# Altium schematic sheet Power,USB_and_Sensor.SchDoc
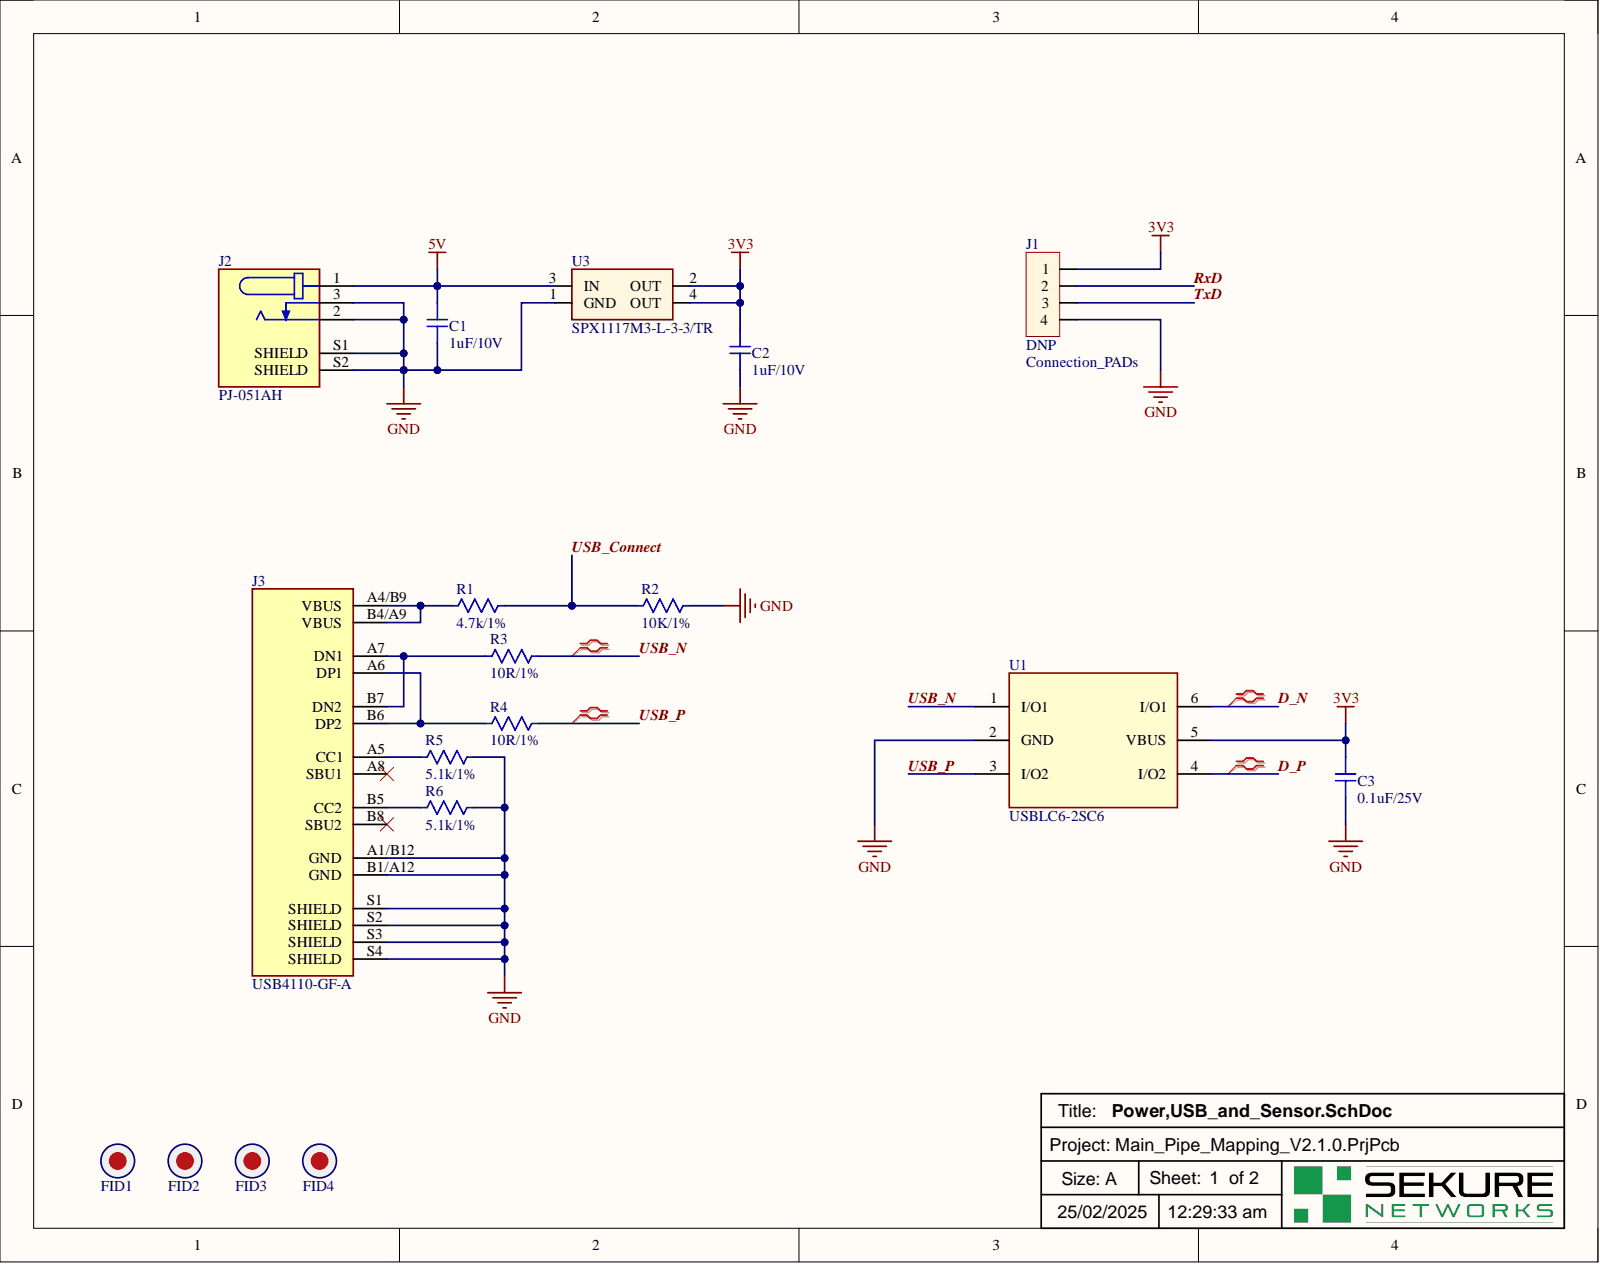
[diagram p0: Power,USB_and_Sensor.SchDoc]

## Parts
C1  value 1uF/10V  [CAP 0603]  (hidden comment: Ceramic Capacitor)
C2  value 1uF/10V  [CAP 0603]  (hidden comment: Ceramic Capacitor)
C3  value 0.1uF/25V  [CAP 0603]  (hidden comment: Ceramic Capacitor)
FID1  Fiducal marks  [Fiducial mark]  (value not drawn)  (no BOM)
FID2  Fiducal marks  [Fiducial mark]  (value not drawn)  (no BOM)
FID3  Fiducal marks  [Fiducial mark]  (value not drawn)  (no BOM)
FID4  Fiducal marks  [Fiducial mark]  (value not drawn)  (no BOM)
J1  value Connection_PADs  Assembly: DNP  [Conn_Pad Small]  (hidden comment: Connector)
J2  value PJ-051AH  [PJ-051AH]  (hidden comment: Connector)
J3  value USB4110-GF-A  [USB4110GFA]  (hidden comment: Connector)
R1  value 4.7k/1%  [RES 0603]  (hidden comment: Resistor)
R2  value 10K/1%  [RES 0603]  (hidden comment: Resistor)
R3  value 10R/1%  [RES 0603]  (hidden comment: Resistor)
R4  value 10R/1%  [RES 0603]  (hidden comment: Resistor)
R5  value 5.1k/1%  [RES 0603]  (hidden comment: Resistor)
R6  value 5.1k/1%  [RES 0603]  (hidden comment: Resistor)
U1  value USBLC6-2SC6  [SOT23-6L]  (hidden comment: TVS Diode)
U3  value SPX1117M3-L-3-3/TR  [SOT-223]  (hidden comment: Regulator)

## Nets
3V3: C2.1 C3.1 J1.1 U1.5(VBUS) U3.2(OUT) U3.4(OUT)
5V: C1.1 J2.1 U3.3(IN)
GND: C1.2 C2.2 C3.2 J1.4 J2.2 J2.3 J2.S1(SHIELD) J2.S2(SHIELD) J3.A1/B12(GND) J3.B1/A12(GND) J3.S1(SHIELD) J3.S2(SHIELD) J3.S3(SHIELD) J3.S4(SHIELD) R2.2 R5.2 R6.1 U1.2(GND) U3.1(GND)
D_N: U1.6(I/O1)
D_P: U1.4(I/O2)
RxD: J1.2
TxD: J1.3
USB_Connect: R1.2 R2.1
USB_N: R3.1 U1.1(I/O1)
USB_P: R4.1 U1.3(I/O2)
NetJ3_A4/B9: J3.A4/B9(VBUS) J3.B4/A9(VBUS) R1.1
NetJ3_A5: J3.A5(CC1) R5.1
NetJ3_A6: J3.A6(DP1) J3.B6(DP2) R4.2
NetJ3_A7: J3.A7(DN1) J3.B7(DN2) R3.2
NetJ3_B5: J3.B5(CC2) R6.2

Not connected: FID1 0; FID2 0; FID3 0; FID4 0; J3 A8(SBU1) B8(SBU2)

## Library data of the parts
C1 C2 = CL10B105KP8NNNC: Multilayer Ceramic Capacitor, 1 uF, 10 V, ± 10%, X7R, 0603 [1608 Metric]; Manufacturer: Samsung; Material: 4241ConductorMaterial; Voltage Rating: 10V; Case Code (Imperial): 0603; Case Code (Metric): 1608; Case/Package: 0603; Category: Ceramic Capacitors; Component Kind: Standard; Construction: Flat; Depth: 0.8mm; Dielectric: X7R; DigiKey: 1276-1946-1-ND; Height: 0.8mm; Lead Free: Lead Free; Length: 1.6mm; Library Name: Ceramic Capacitors.IntLib; Max Operating Temperature: 125°C; Min Operating Temperature: -55°C; Mounting Technology: Surface Mount; P/N: CL10B105KP8NNNC; Package Quantity: 1; Packaging: Tape and Reel; Pricing: 1=0.1, 10=0.026, 50=0.0146, 100=0.0114, 500=0.00858, 1000=0.00674 (USD); Radiation Hardening: No; RoHS: Yes; RoHS Compliant: Yes; Series: CL; Stock: 7012743; Supplier: Digikey; Thickness: 0.035inch; Tolerance: 10%; Voltage Rating (DC): 10V; Width: 0.031inch
C3 = CL10B104KA8NNNC: Multilayer Ceramic Capacitor, 0.1 uF, 25 V, ± 10%, X7R, 0603 [1608 Metric]; Manufacturer: Samsung; Material: 4241ConductorMaterial; Voltage: 25V; Case Code (Imperial): 0603; Case Code (Metric): 1608; Case/Package: 0603; Category: Ceramic Capacitors; Component Kind: Standard; ComponentLink1Description: https://datasheet.ciiva.com/25805/cl10b104ka8nnnc-25805120.pdf?src-supplier=Digikey; ComponentLink1URL: https://datasheet.ciiva.com/25805/cl10b104ka8nnnc-25805120.pdf?src-supplier=Digikey; ComponentLink2Description: https://datasheet.ciiva.com/26838/getdatasheetpartid-354050-26838409.pdf?src-supplier=Verical; ComponentLink2URL: https://datasheet.ciiva.com/26838/getdatasheetpartid-354050-26838409.pdf?src-supplier=Verical; Depth: 0.8mm; Dielectric: X7R; DigiKey: 1276-1006-1-ND; Height: 0.8mm; Lead Free: Lead Free; Length: 1.6mm; Library Name: Ceramic Capacitors.IntLib; Max Operating Temperature: 125°C; Min Operating Temperature: -55°C; Mounting Technology: Surface Mount; P/N: CL10B104KA8NNNC; Package Quantity: 1; Packaging: Tape and Reel; Pricing: 1=0.1, 10=0.024, 50=0.0136, 100=0.0105, 500=0.00792, 1000=0.00622 (USD); Radiation Hardening: No; RoHS: Yes; RoHS Compliant: Yes; Series: CL; Stock: 708971; Supplier: Digikey; Thickness: 0.035inch; Tolerance: 10%; Voltage Rating: 25V; Voltage Rating (DC): 25V; Width: 0.031inch
FID1-FID4 = Fiducial mark: Fiducial mark mini  in top placement.; Number: 1; Library Name: Connectors.IntLib
J1 = Conc_Pad_Small: 4 PCB SMD PADs for Connection; Component Kind: Standard; ComponentLink1Description: https://datasheet.ciiva.com/5741/1605505-5741440.pdf?src-supplier=Farnell; ComponentLink1URL: https://datasheet.ciiva.com/5741/1605505-5741440.pdf?src-supplier=Farnell; ComponentLink2Description: https://datasheet.ciiva.com/30254/eng-cs-1308389-eurostyle-terminal-blocks-0607-30254374.pdf?src-supplier=Onlinecomponents.com; ComponentLink2URL: https://datasheet.ciiva.com/30254/eng-cs-1308389-eurostyle-terminal-blocks-0607-30254374.pdf?src-supplier=Onlinecomponents.com; ComponentLink3Description: https://datasheet.ciiva.com/3141/eng-cs-1308389-eurostyle-terminal-blocks-0607-3141117.pdf?src-supplier=Avnet; ComponentLink3URL: https://datasheet.ciiva.com/3141/eng-cs-1308389-eurostyle-terminal-blocks-0607-3141117.pdf?src-supplier=Avnet; ComponentLink4Description: https://datasheet.ciiva.com/14609/70087596-14609846.pdf?src-supplier=Allied+Electronics; ComponentLink4URL: https://datasheet.ciiva.com/14609/70087596-14609846.pdf?src-supplier=Allied+Electronics; ComponentLink5Description: https://datasheet.ciiva.com/823/eng-cd-282834-c1-823474.pdf?src-supplier=Digikey; ComponentLink5URL: https://datasheet.ciiva.com/823/eng-cd-282834-c1-823474.pdf?src-supplier=Digikey; Library Name: Connectors.IntLib
J2 = PJ-051AH: 2.0 x 6.0 mm, 5.0 A, Horizontal, Through Hole, Shielded, Dc Power Jack Connector; Manufacturer: CUI Devices; Category: Power Connectors; Component Kind: Standard; ComponentLink1Description: https://www.cuidevices.com/product/resource/digikeypdf/pj-051ah.pdf?src-supplier=Digikey; ComponentLink1URL: https://www.cuidevices.com/product/resource/digikeypdf/pj-051ah.pdf?src-supplier=Digikey; ComponentLink2Description: https://datasheet.octopart.com/PJ-051AH-CUI-Devices-datasheet-137760691.pdf?src-supplier=Future+Electronics; ComponentLink2URL: https://datasheet.octopart.com/PJ-051AH-CUI-Devices-datasheet-137760691.pdf?src-supplier=Future+Electronics; ComponentLink3Description: https://datasheet.octopart.com/PJ-051AH-CUI-Devices-datasheet-141000361.pdf?src-supplier=Master+Electronics; ComponentLink3URL: https://datasheet.octopart.com/PJ-051AH-CUI-Devices-datasheet-141000361.pdf?src-supplier=Master+Electronics; DigiKey: CP-051AH-ND; Library Name: Connectors.IntLib; P/N: PJ-051AH; Pricing: 1=0.63, 10=0.532, 25=0.5108, 50=0.4998, 100=0.4781, 250=0.43464, 500=0.39118, 1000=0.38261 (USD); RoHS: Yes; Stock: 71631; Supplier: Digikey
J3 = USB4110-GF-A: USB Connector, 16 Contact(s), Male, Right Angle, Surface Mount Terminal, Locking, Receptacle; Manufacturer: Global Connector Technology; Category: USB Connectors; Component Kind: Standard; ComponentLink1Description: https://gct.co/files/drawings/usb4110.pdf?src-supplier=Digikey; ComponentLink1URL: https://gct.co/files/drawings/usb4110.pdf?src-supplier=Digikey; DigiKey: 2073-USB4110-GF-A-1-ND; Library Name: Connectors.IntLib; P/N: USB4110-GF-A; Pricing: 1=1.35, 10=1.143, 25=1.0964, 50=1.0732, 100=1.0266, 250=0.93324, 500=0.83992 (USD); RoHS: No; Stock: 41079; Supplier: Digikey
R1 = ERJ-3EKF4701V: RES SMD, 4.7K 0603, 0.1W, 1%; Manufacturer: Panasonic; Max Power Dissipation: 100mW; Resistance: 4.7kR; Temperature Coefficient: 100ppm/°C; Voltage Rating: 75V; Case Code (Imperial): 0603; Case Code (Metric): 1608; Case/Package: 0603; Component Kind: Standard; ComponentLink1Description: https://datasheet.ciiva.com/16449/aoa0000ce2-16449479.pdf?src-supplier=Future+Electronics; ComponentLink1URL: https://datasheet.ciiva.com/16449/aoa0000ce2-16449479.pdf?src-supplier=Future+Electronics; ComponentLink2Description: https://datasheet.ciiva.com/16511/aoa0000ce2-16511783.pdf?src-supplier=Avnet+Asia; ComponentLink2URL: https://datasheet.ciiva.com/16511/aoa0000ce2-16511783.pdf?src-supplier=Avnet+Asia; ComponentLink3Description: https://datasheet.ciiva.com/9195/aoa0000ce2-9195740.pdf?src-supplier=Avnet; ComponentLink3URL: https://datasheet.ciiva.com/9195/aoa0000ce2-9195740.pdf?src-supplier=Avnet; ComponentLink4Description: https://datasheet.ciiva.com/16758/70260748-16758189.pdf?src-supplier=Allied+Electronics; ComponentLink4URL: https://datasheet.ciiva.com/16758/70260748-16758189.pdf?src-supplier=Allied+Electronics; ComponentLink5Description: https://datasheet.ciiva.com/24160/aoa0000ce2-24160445.pdf?src-supplier=Mouser; ComponentLink5URL: https://datasheet.ciiva.com/24160/aoa0000ce2-24160445.pdf?src-supplier=Mouser; ComponentLink6Description: https://datasheet.ciiva.com/46372/1678782-46372717.pdf?src-supplier=Element14; ComponentLink6URL: https://datasheet.ciiva.com/46372/1678782-46372717.pdf?src-supplier=Element14; ComponentLink7Description: https://datasheet.ciiva.com/17213/aoa0000ce2-17213182.pdf?src-supplier=Avnet+Europe; ComponentLink7URL: https://datasheet.ciiva.com/17213/aoa0000ce2-17213182.pdf?src-supplier=Avnet+Europe; ComponentLink8Description: https://datasheet.ciiva.com/7830/426256-7830985.pdf?src-supplier=Element14; ComponentLink8URL: https://datasheet.ciiva.com/7830/426256-7830985.pdf?src-supplier=Element14; ComponentLink9Description: https://datasheet.ciiva.com/46372/1678782-46372716.pdf?src-supplier=Element14; ComponentLink9URL: https://datasheet.ciiva.com/46372/1678782-46372716.pdf?src-supplier=Element14; ComponentLink10Description: https://datasheet.ciiva.com/7830/aoa0000ce2-7830735.pdf?src-supplier=Element14; ComponentLink10URL: https://datasheet.ciiva.com/7830/aoa0000ce2-7830735.pdf?src-supplier=Element14; Composition: Thick Film; Contact Plating: Tin; Depth: 0.8mm; DigiKey: P4.70KHDKR-ND; Features: Automotive AEC-Q200; Height: 0.022inch; Lead Free: Lead Free; Length: 1.6mm; Library Name: Resistors.IntLib; Max Operating Temperature: 155°C; Military Standard: Not; Min Operating Temperature: -55°C; Mount: Surface Mount; Number of Pins: 2; Number of Terminations: 2; P/N: ERJ-3EKF4701V; Package Quantity: 1; Packaging: Tape and Reel; Power Rating: 100mW; Pricing 1: 1=0.10000, 10=0.05700, 100=0.02340, 1000=0.01049, 2500=0.00911 (USD); Radiation Hardening: No; REACH SVHC: No SVHC; RoHS: Yes; Stock 1: 588466; Supplier 1: Digi-Key; Termination: SMD/SMT; Tolerance: 1%; Voltage Rating (DC): 75V; Weight: 7.1E-05oz; Width: 0.031inch
R2 = RC0603FR-0710KL: RES SMD 10K OHM 1% 1/10W 0603; Manufacturer: Yageo; Power Rating: 100mW; Resistance: 10kR; Temperature Coefficient: 100ppm/°C; Voltage Rating: 75V; Voltage Rating (DC): 50V; Case Code (Imperial): 0603; Case Code (Metric): 1608; Case/Package: 0603; Component Kind: Standard; ComponentLink1Description: https://datasheet.ciiva.com/7660/38590-7660789.pdf?src-supplier=Element14; ComponentLink1URL: https://datasheet.ciiva.com/7660/38590-7660789.pdf?src-supplier=Element14; ComponentLink2Description: https://datasheet.ciiva.com/15189/getdatasheetpartid-409289-15189591.pdf?src-supplier=Verical; ComponentLink2URL: https://datasheet.ciiva.com/15189/getdatasheetpartid-409289-15189591.pdf?src-supplier=Verical; ComponentLink3Description: https://datasheet.ciiva.com/46440/1868787-46440891.pdf?src-supplier=Element14; ComponentLink3URL: https://datasheet.ciiva.com/46440/1868787-46440891.pdf?src-supplier=Element14; ComponentLink4Description: https://datasheet.ciiva.com/7983/443971-7983596.pdf?src-supplier=Element14; ComponentLink4URL: https://datasheet.ciiva.com/7983/443971-7983596.pdf?src-supplier=Element14; ComponentLink5Description: https://datasheet.ciiva.com/7746/1738695-7746239.pdf?src-supplier=Element14; ComponentLink5URL: https://datasheet.ciiva.com/7746/1738695-7746239.pdf?src-supplier=Element14; ComponentLink6Description: https://datasheet.ciiva.com/22747/443971-22747519.pdf?src-supplier=Element14; ComponentLink6URL: https://datasheet.ciiva.com/22747/443971-22747519.pdf?src-supplier=Element14; Composition: Thick Film; Depth: 0.8mm; DigiKey: 311-10.0KHRDKR-ND; Height: 0.45mm; Lead Free: Lead Free; Lead Pitch: 0.3mm; Length: 1.6mm; Library Name: Resistors.IntLib; Max Operating Temperature: 155°C; Military Standard: Not; Min Operating Temperature: -55°C; Number of Pins: 2; P/N: RC0603FR-0710KL; Packaging: Tape and Reel; Pricing 1: 1=0.10000, 10=0.02300, 100=0.00940, 1000=0.00421, 2500=0.00366 (USD); REACH SVHC: No SVHC; RoHS: Yes; Stock 1: 55833360; Supplier 1: Digi-Key; Termination: SMD/SMT; Tolerance: 1%; Weight: 7.1E-05oz; Width: 0.8mm
R3 R4 = CRCW060310R0FKEA: RES SMD 10 OHM 1% 1/10W 0603; Manufacturer: Vishay; Max Power Dissipation: 100mW; Resistance: 10R; Temperature Coefficient: 100ppm/°C; Voltage Rating: 75V; Case Code (Imperial): 0603; Case Code (Metric): 1608; Case/Package: 0603; Component Kind: Standard; ComponentLink1Description: https://datasheet.ciiva.com/11808/0900766b80d98afc-11808660.pdf?src-supplier=RSComponents; ComponentLink1URL: https://datasheet.ciiva.com/11808/0900766b80d98afc-11808660.pdf?src-supplier=RSComponents; ComponentLink2Description: https://datasheet.ciiva.com/2465/dcrcwe3-2465699.pdf?src-supplier=Digi-Key; ComponentLink2URL: https://datasheet.ciiva.com/2465/dcrcwe3-2465699.pdf?src-supplier=Digi-Key; ComponentLink3Description: https://datasheet.ciiva.com/2465/dcrcwe3-2465701.pdf?src-supplier=Digi-Key; ComponentLink3URL: https://datasheet.ciiva.com/2465/dcrcwe3-2465701.pdf?src-supplier=Digi-Key; ComponentLink4Description: https://datasheet.ciiva.com/16740/dcrcwe3-16740661.pdf?src-supplier=Future+Electronics; ComponentLink4URL: https://datasheet.ciiva.com/16740/dcrcwe3-16740661.pdf?src-supplier=Future+Electronics; ComponentLink5Description: https://datasheet.ciiva.com/26316/fld-crcw-e3-data-en-26316582.pdf?src-supplier=Distrelec; ComponentLink5URL: https://datasheet.ciiva.com/26316/fld-crcw-e3-data-en-26316582.pdf?src-supplier=Distrelec; ComponentLink6Description: https://datasheet.ciiva.com/16781/70201119-16781847.pdf?src-supplier=Allied+Electronics; ComponentLink6URL: https://datasheet.ciiva.com/16781/70201119-16781847.pdf?src-supplier=Allied+Electronics; ComponentLink7Description: https://datasheet.ciiva.com/22673/1697337-22673071.pdf?src-supplier=Element14; ComponentLink7URL: https://datasheet.ciiva.com/22673/1697337-22673071.pdf?src-supplier=Element14; ComponentLink8Description: https://datasheet.ciiva.com/2465/dcrcwe3-2465700.pdf?src-supplier=Digi-Key; ComponentLink8URL: https://datasheet.ciiva.com/2465/dcrcwe3-2465700.pdf?src-supplier=Digi-Key; ComponentLink9Description: https://datasheet.ciiva.com/3256/dcrcwe3-3256955.pdf?src-supplier=Avnet; ComponentLink9URL: https://datasheet.ciiva.com/3256/dcrcwe3-3256955.pdf?src-supplier=Avnet; ComponentLink10Description: https://datasheet.ciiva.com/5547/dcrcwe3-109170-5547342.pdf?src-supplier=Mouser; ComponentLink10URL: https://datasheet.ciiva.com/5547/dcrcwe3-109170-5547342.pdf?src-supplier=Mouser; Composition: Thick Film; Contact Plating: Tin; Depth: 0.85mm; DigiKey: 541-10.0HDKR-ND; Features: Automotive AEC-Q200; Height: 0.45mm; Lead Free: Lead Free; Length: 1.55mm; Library Name: Resistors.IntLib; Max Operating Temperature: 155°C; Military Standard: Not; Min Operating Temperature: -55°C; Mount: Surface Mount; Number of Pins: 2; Number of Terminations: 2; P/N: CRCW060310R0FKEA; Package Quantity: 10; Packaging: Tape and Reel; Power Rating: 100mW; Pricing 1: 1=0.10000, 10=0.03400, 100=0.01390, 1000=0.00623, 2500=0.00540 (USD); REACH SVHC: Unknown; RoHS: Yes; Stock 1: 297502; Supplier 1: Digi-Key; Termination: SMD/SMT; Tolerance: 1%; Voltage Rating (DC): 75V; Weight: 7.1E-05oz; Width: 0.033inch
R5 R6 = ERJ-3EKF5101V: Res Thick Film 0603 5.1K Ohm 1% 1/10W ±100ppm/°C Molded SMD Punched Carrier T/R; Manufacturer: Panasonic; Max Power Dissipation: 100mW; Resistance: 5.1kR; Voltage Rating: 75V; Case Code (Imperial): 0603; Case Code (Metric): 1608; Case/Package: 0603; Category: Chip SMD Resistors; Component Kind: Standard; ComponentLink1Description: https://datasheet.ciiva.com/5860/426256-5860664.pdf?src-supplier=Farnell; ComponentLink1URL: https://datasheet.ciiva.com/5860/426256-5860664.pdf?src-supplier=Farnell; ComponentLink2Description: https://datasheet.ciiva.com/28547/1678782-28547194.pdf?src-supplier=Element14; ComponentLink2URL: https://datasheet.ciiva.com/28547/1678782-28547194.pdf?src-supplier=Element14; ComponentLink3Description: https://datasheet.ciiva.com/28686/1678782-28686921.pdf?src-supplier=Element14; ComponentLink3URL: https://datasheet.ciiva.com/28686/1678782-28686921.pdf?src-supplier=Element14; ComponentLink4Description: https://datasheet.ciiva.com/16785/70260748-16785996.pdf?src-supplier=Allied+Electronics; ComponentLink4URL: https://datasheet.ciiva.com/16785/70260748-16785996.pdf?src-supplier=Allied+Electronics; ComponentLink5Description: https://datasheet.ciiva.com/16440/aoa0000ce3-16440166.pdf?src-supplier=Future+Electronics; ComponentLink5URL: https://datasheet.ciiva.com/16440/aoa0000ce3-16440166.pdf?src-supplier=Future+Electronics; ComponentLink6Description: https://datasheet.ciiva.com/16523/aoa0000ce2-16523461.pdf?src-supplier=Avnet+Asia; ComponentLink6URL: https://datasheet.ciiva.com/16523/aoa0000ce2-16523461.pdf?src-supplier=Avnet+Asia; Construction: Rectangular; Contact Plating: Tin; Depth: 0.8mm; DigiKey: P5.10KHCT-ND; Features: Automotive AEC-Q200; Height: 0.022inch; Lead Free: Lead Free; Length: 1.6mm; Library Name: Resistors.IntLib; Max Operating Temperature: 155°C; Military Standard: Not; Min Operating Temperature: -55°C; Mount: Surface Mount; Number of Terminations: 2; P/N: ERJ-3EKF5101V; Package Quantity: 1; Packaging: Tape and Reel; Power Rating: 100mW; Pricing 1: 1=0.10000, 10=0.04200, 100=0.01700, 1000=0.00762, 2500=0.00661 (USD); Radiation Hardening: No; REACH SVHC: No SVHC; Resistance Tolerance: 1%; Resistor Type: Thick Film; RoHS: Yes; RoHS Compliant: Yes; Series: ERJ; Size Code: 0603; Stock 1: 330; Supplier 1: Digi-Key; Temperature Coefficient: 100ppm/°C; Termination: SMD/SMT; Tolerance: 1%; Voltage Rating (DC): 75V; Weight: 7.1E-05oz; Width: 0.8mm
U1 = USBLC6-2SC6: Trans Voltage Suppressor Diode, 5V V(RWM), Unidirectional, 1 Element, Silicon; Manufacturer: STMicroelectronics; Additional Feature: HIGH RELIABILITY; Clamping Voltage-Max: 17V; Min Breakdown Voltage: 6V; Number of Elements: 1; Package Body Material: Plastic; Qualification Status: Not Qualified; Reference Standard: IEC-61000-4-2; Category: TVS Diodes; Component Kind: Standard; ComponentLink1Description: https://datasheet.ciiva.com:443/pdfs/VipMasterIC/IC/SGST/SGSTS30336/SGSTS30336-1.pdf?src-supplier=IHS+Markit; ComponentLink1URL: https://datasheet.ciiva.com:443/pdfs/VipMasterIC/IC/SGST/SGSTS30336/SGSTS30336-1.pdf?src-supplier=IHS+Markit; ComponentLink2Description: https://datasheet.ciiva.com/1268/1690354-1268384.pdf?src-supplier=Element14; ComponentLink2URL: https://datasheet.ciiva.com/1268/1690354-1268384.pdf?src-supplier=Element14; ComponentLink3Description: https://datasheet.ciiva.com/4508/cd00050750-113117-4508433.pdf?src-supplier=Mouser; ComponentLink3URL: https://datasheet.ciiva.com/4508/cd00050750-113117-4508433.pdf?src-supplier=Mouser; ComponentLink4Description: https://datasheet.ciiva.com/15143/getdatasheetpartid-148465-15143789.pdf?src-supplier=Verical; ComponentLink4URL: https://datasheet.ciiva.com/15143/getdatasheetpartid-148465-15143789.pdf?src-supplier=Verical; ComponentLink5Description: https://datasheet.ciiva.com/16200/cd00050750-16200026.pdf?src-supplier=Future+Electronics; ComponentLink5URL: https://datasheet.ciiva.com/16200/cd00050750-16200026.pdf?src-supplier=Future+Electronics; ComponentLink6Description: https://datasheet.ciiva.com/41444/001184109-da-01-en-ic-esd-protecti-usblc6-2sc6-sot-23-6-stm-41444156.pdf?src-supplier=Conrad; ComponentLink6URL: https://datasheet.ciiva.com/41444/001184109-da-01-en-ic-esd-protecti-usblc6-2sc6-sot-23-6-stm-41444156.pdf?src-supplier=Conrad; DigiKey: 497-5235-1-ND; Diode Element Material: SILICON; Diode Type: TRANS VOLTAGE SUPPRESSOR; JESD-30 Code: R-PDSO-G6; JESD-609 Code: e3; Library Name: Diode.SchLib; Max Operating Temperature: 125°C; Min Operating Temperature: -40°C; Moisture Sensitivity Level: 1; P/N: USBLC6-2SC6; Package Shape: Rectangular; Package Style: SMALL OUTLINEMeter; Peak Reflow Temperature (Cel): 260°C; Pins: 6; Polarity: Unidirectional; Pricing: 1=0.35, 10=0.273, 100=0.1636, 500=0.1515, 1000=0.10302 (USD); Reach SVHC Compliant: Yes; Rep Pk Reverse Voltage-Max: 5V; RoHS: Yes; RoHS Compliant: Yes; Stock: 102967; Supplier: Digikey; Surface Mount: Yes; Technology: AVALANCHE; Terminal Finish: Tin; Terminal Form: Gull Wing; Terminal Position: DUAL
U3 = SPX1117M3-L-3-3/TR: Linear Voltage Regulator IC Positive Fixed 1 Output 800mA SOT-223-3; Manufacturer: MaxLinear, Inc.; Accuracy: 1%; Dropout Voltage: 1.3V; Input Bias Current: 4mA; Max Input Voltage: 15V; Max Output Voltage: 3.3V; Min Input Voltage: 3.8V; Number of Outputs: 1; Case/Package: SOT-223; Category: Voltage Regulators - Linear; Component Kind: Standard; ComponentLink1Description: https://datasheet.ciiva.com/2064/reg1117-2064563.pdf?src-supplier=Digi-Key; ComponentLink1URL: https://datasheet.ciiva.com/2064/reg1117-2064563.pdf?src-supplier=Digi-Key; DigiKey: 1016-1848-2-ND; Lead Free: Lead Free; Library Name: Regulators.IntLib; Max Operating Temperature: 125°C; Max Output Current: 800mA; Min Current Limit: 800mA; Min Operating Temperature: 0°C; Min Output Voltage: 3.3V; Nominal Output Voltage: 3.3V; Number of Pins: 4; Number of Regulators: 1; Output Current: 800mA; Output Type: Fixed; Output Voltage: 3.3V; Output Voltage Accuracy: 1%; P/N: SPX1117M3-L-3-3/TR; Package Quantity: 80; Packaging: Rail/Tube; Polarity: Positive; Pricing 1: 1=3.08000, 10=2.76700, 25=2.61040, 100=2.22390, 250=2.08820, 500=1.82718, 1000=1.72375 (USD); Quiescent Current: 4mA; Radiation Hardening: No; REACH SVHC: No SVHC; RoHS: Yes; RoHS Compliant: Yes; Stock 1: 3710; Supplier 1: Digi-Key
